# Altium schematic sheet LoconetConnection.SchDoc
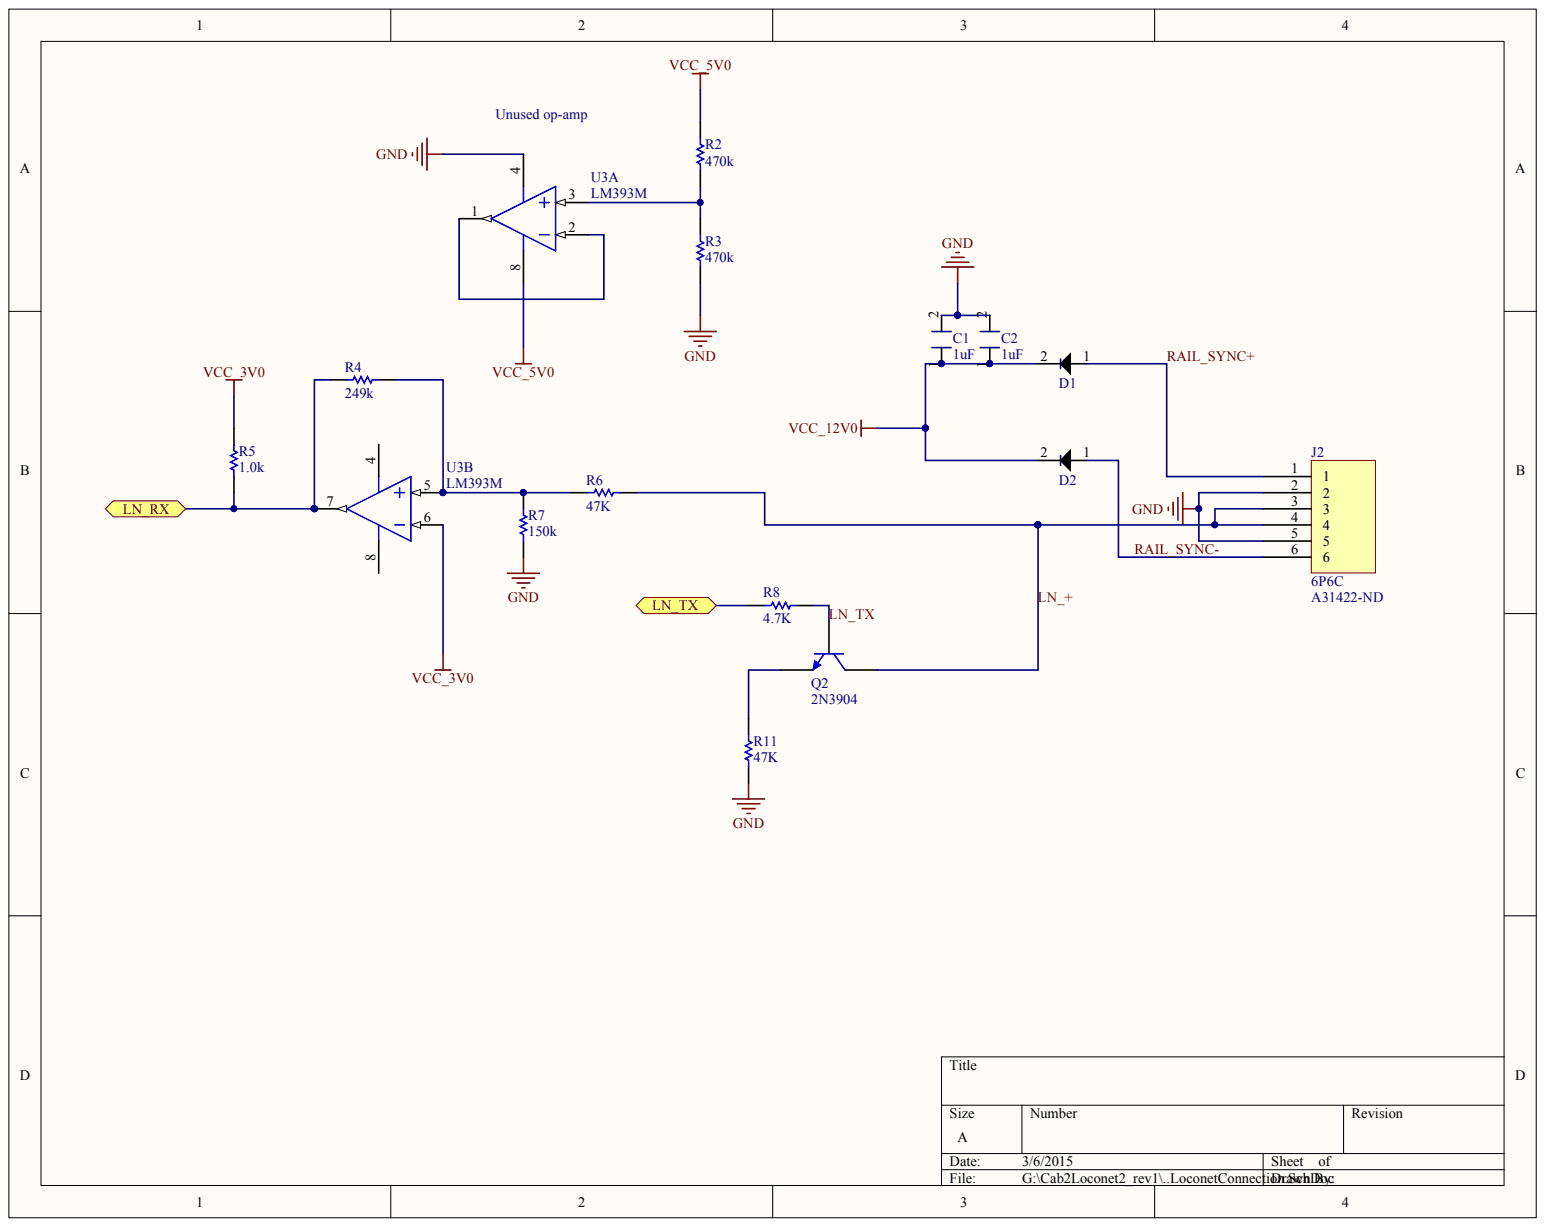
[diagram p0: LoconetConnection.SchDoc]

## Parts
C1  1uF
C2  1uF
D1  CD214A-B140LF, 1A, 40V  (value not drawn)
D2  CD214A-B140LF, 1A, 40V  (value not drawn)
J2  6P6C  Supplier Part Number 1: A31422-ND
Q2  2N3904
R2  470k
R3  470k
R4  249k
R5  1.0k
R6  47K
R7  150k
R8  4.7K
R11  47K
U3  LM393M  (parts A,B)

## Ports
LN_RX, LN_TX

## Nets
GND: C1.2 C2.2 J2.2 J2.5 R3.1 R7.1 R11.1 U3.4
VCC_3V0: R5.2 U3.6
VCC_5V0: R2.2 U3.8
VCC_12V0: C1.1 C2.1 D1.2 D2.2
LN_+: J2.3 J2.4 Q2.3 R6.2
LN_RX: R4.1 R5.1 U3.7 ; port LN_RX
LN_TX: Q2.2 R8.2
RAIL_SYNC+: D1.1 J2.1
RAIL_SYNC-: D2.1 J2.6
NetQ2_1: Q2.1 R11.2
NetR2_1: R2.1 R3.2 U3.3
NetR4_2: R4.2 R6.1 R7.2 U3.5
NetR8_1: R8.1 ; port LN_TX
NetU3_1: U3.1 U3.2

## Library data of the parts
C1 C2 = (no symbol name): Manufacturer: TDK; Manufacturer No: C1608X7R1C105M; Supplier 1: Digi-Key; Supplier Part Number 1: 445-5131-2-ND
D1 D2 = (no symbol name): Manufacturer: Bourns; Manufacturer No: CD214A-B140LF; Supplier 1: Digi-Key; Supplier Part Number 1: CD214A-B140LFCT-ND
R2 R3 = (no symbol name): Manufacturer: Panasonic; Manufacturer No: ERJ-3GEYJ474V; Supplier 1: Digi-Key; Supplier Part Number 1: P470KGCT-ND
R4 = (no symbol name): Manufacturer No: RG2012P-2493-B-T5; Manufacturer: Susumu; Supplier 1: Digi-Key; Supplier Part Number 1: RG20P249KBCT-ND
R5 = (no symbol name): Manufacturer: Panasonic; Manufacturer No: ERJ-3EKF1001V; Supplier 1: Digi-Key; Supplier Part Number 1: P1.00KHCT-ND
R6 R11 = (no symbol name): Manufacturer: Vishay/Dale; Manufacturer No: CRCW040247K0FKED; Supplier 1: Digi-Key; Supplier Part Number 1: 541-47.0KLCT-ND
R7 = (no symbol name): Manufacturer No: RG2012P-154-B-T5; Manufacturer: Susumu; Supplier 1: Digi-Key; Supplier Part Number 1: RG20P150KBCT-ND
R8 = (no symbol name): Manufacturer: Panasonic - ECG; Manufacturer No: ERJ-2GEJ472X; Supplier 1: Digi-Key; Supplier Part Number 1: P4.7KJDKR-ND
U3 = (no symbol name): Manufacturer: National Semiconductor; PackageTopMarking: NSZXTT*LM*393M; PowerWise: N; CompType: Amplifiers; High-Reliability: Y; DatasheetVersion: Aug-2002; PackageVersion: revM, May-2009; PackageReference: M08A; PackageDescription: SOIC Narrow, 4.9 x 3.9 x 1.45mm, 8 Lead, 1.27mm Pitch; PartNumber: LM393M; RoHS: N; PinCount: 8; Code_JEDEC: MS-012-AA; ComponentLink1Description: Manufacturer URL; ComponentLink1URL: http://www.national.com/; ComponentLink2Description: LM393 Datasheet; ComponentLink2URL: http://www.national.com/ds/LM/LM393.pdf; ComponentLink3Description: LM393 Web Page; ComponentLink3URL: http://www.national.com/pf/LM/LM393.html; ComponentLink4Description: M08A Package Page; ComponentLink4URL: http://www.national.com/packaging/folders/m08a.html; Code_IPC: SOIC127P600-8
J2 Q2 = (no symbol name)
  J2: Supplier 1: Digi-Key
  Q2: Published: 8-Jun-2000; LatestRevisionDate: 15-Jan-2003; LatestRevisionNote: PCB Footprint 'BCY-W3/D4.7' replaced; DatasheetVersion: 1997; PackageVersion: Sep-1998; PackageReference: TO-92A; PackageDescription: TO, Flat Index; 3 In-Line, Axial Leads; Body Dia. 4.5mm; Leads 0.51 x 0.48 mm (max); ComponentLink1Description: Manufacturer Link; ComponentLink1URL: http://www.fairchildsemi.com/; ComponentLink2Description: Datasheet; ComponentLink2URL: http://www.fairchildsemi.com/ds/2N%2F2N3904.pdf; Publisher: Altium Limited
